# Altium schematic sheet big-auv-power.SchDoc(big-auv-power)
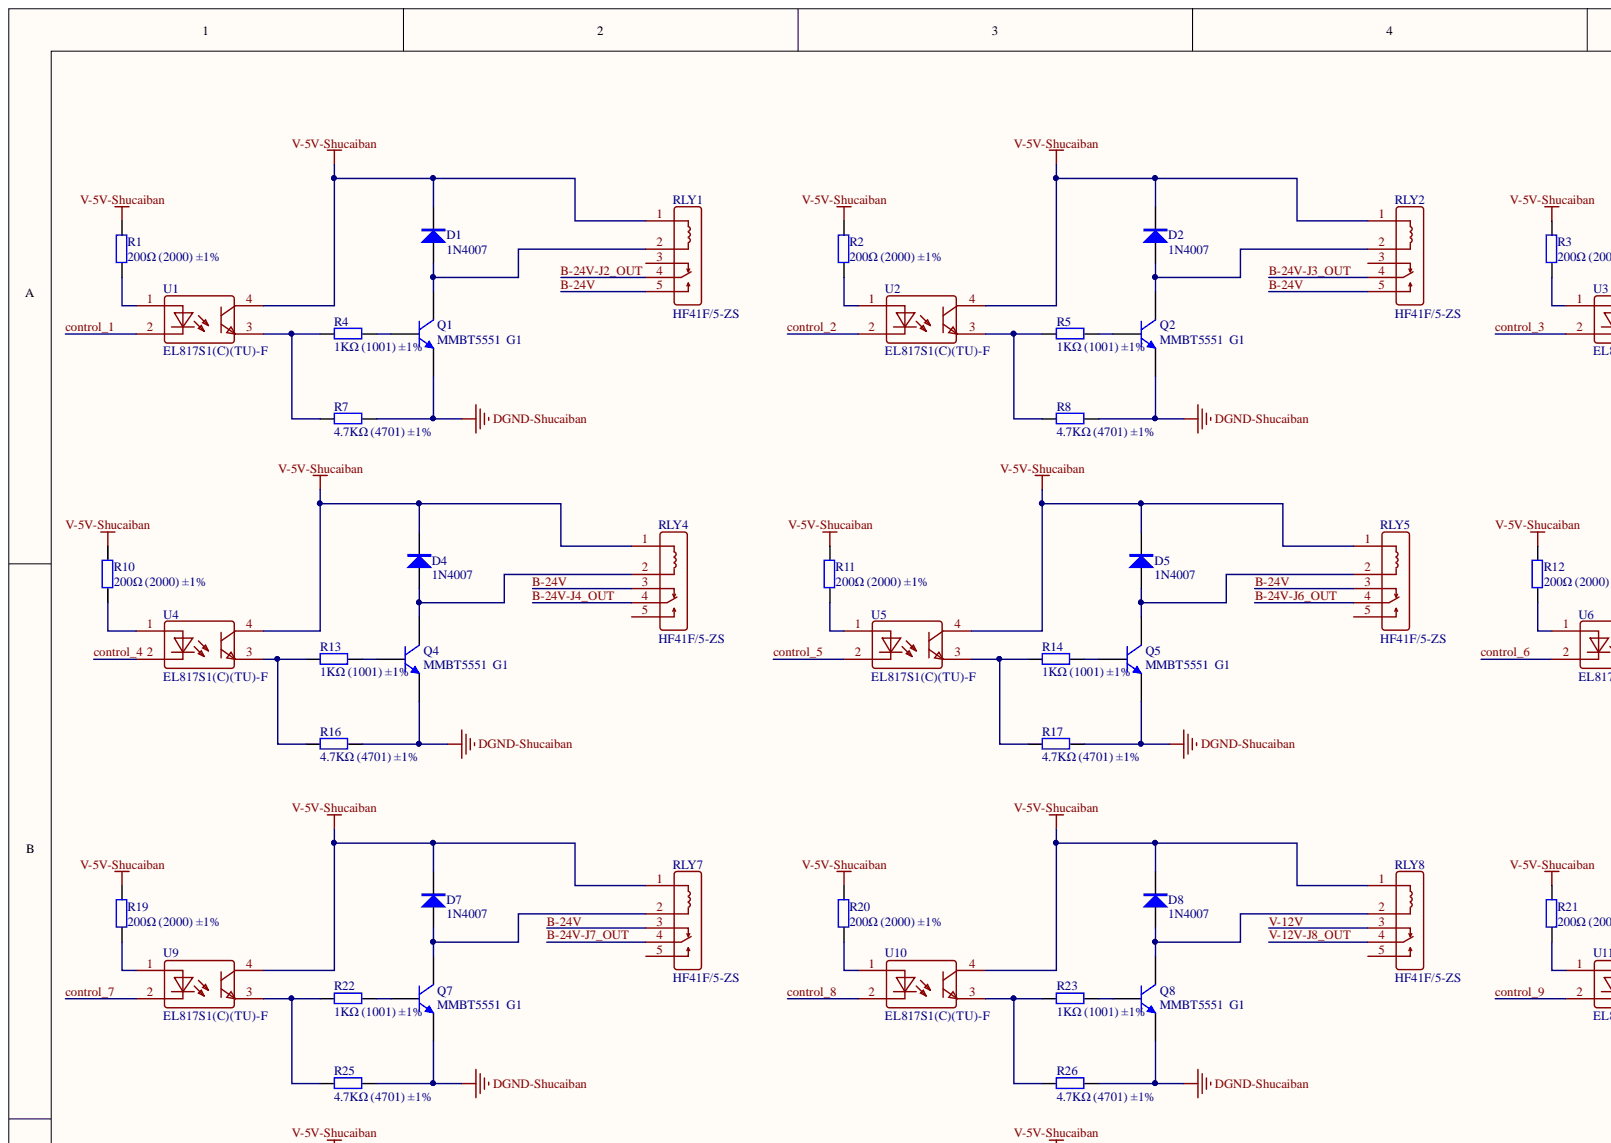
[diagram p0: big-auv-power.SchDoc, top left]
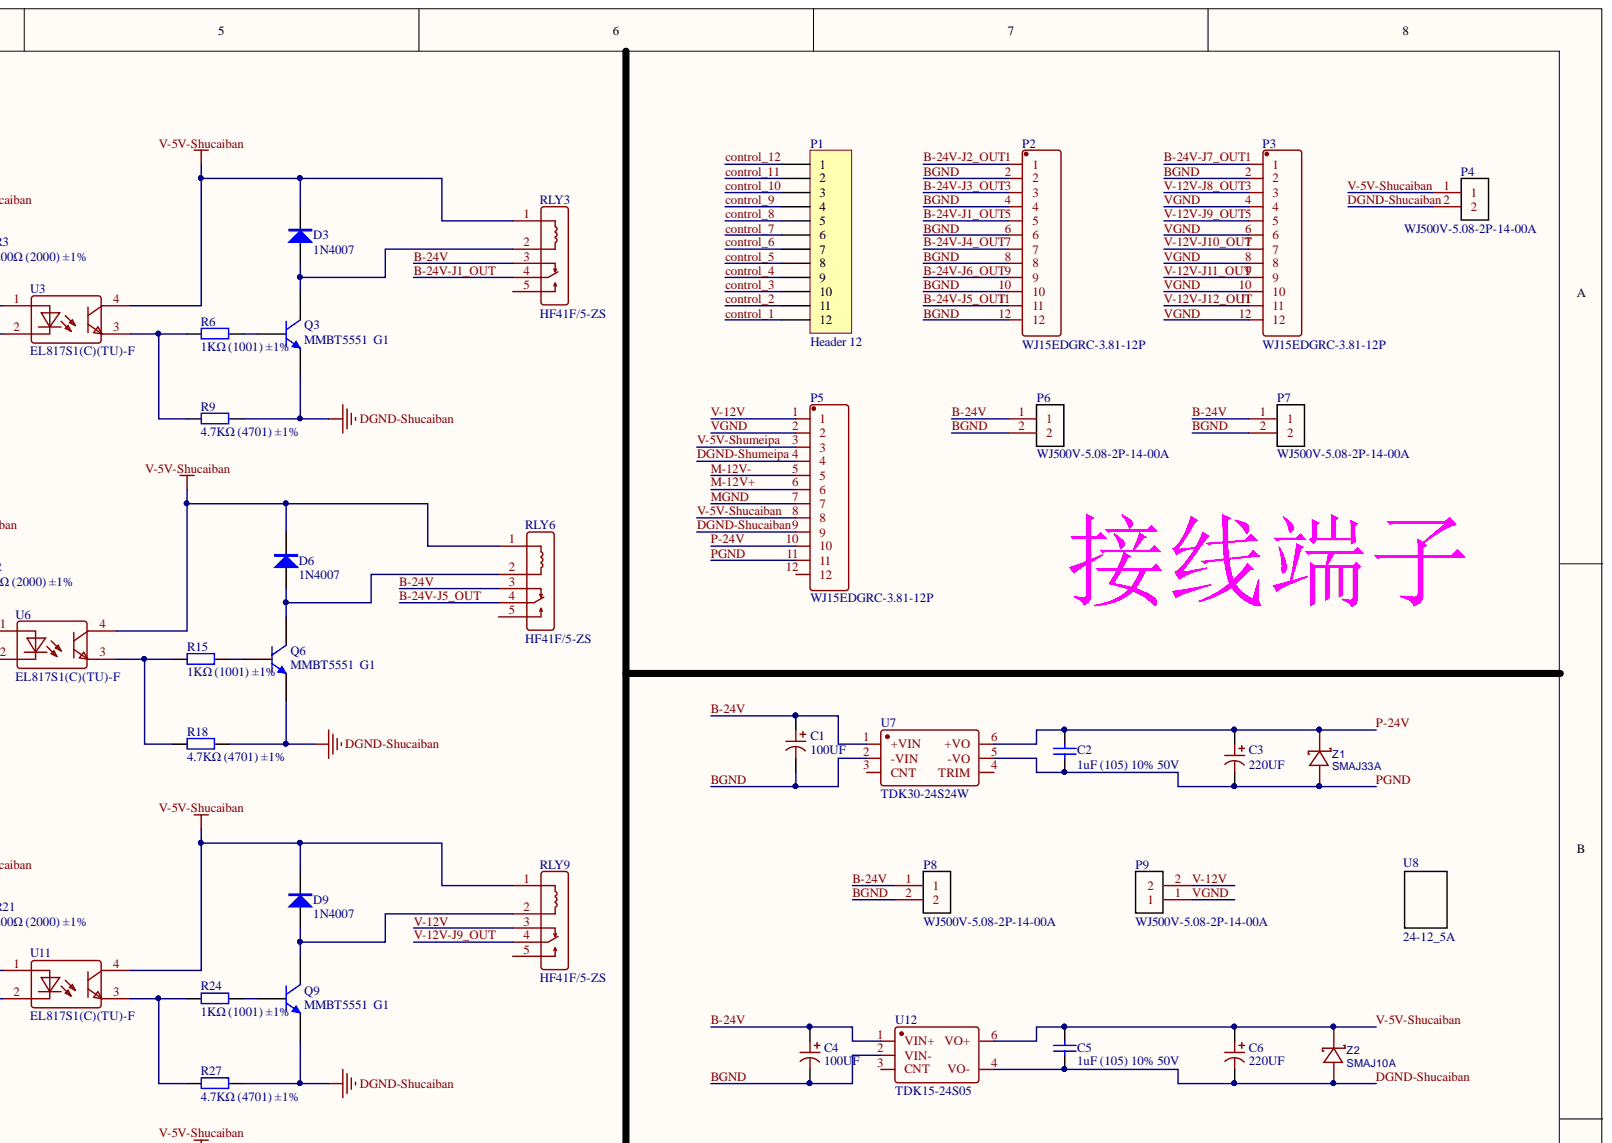
[diagram p1: big-auv-power.SchDoc, top right]
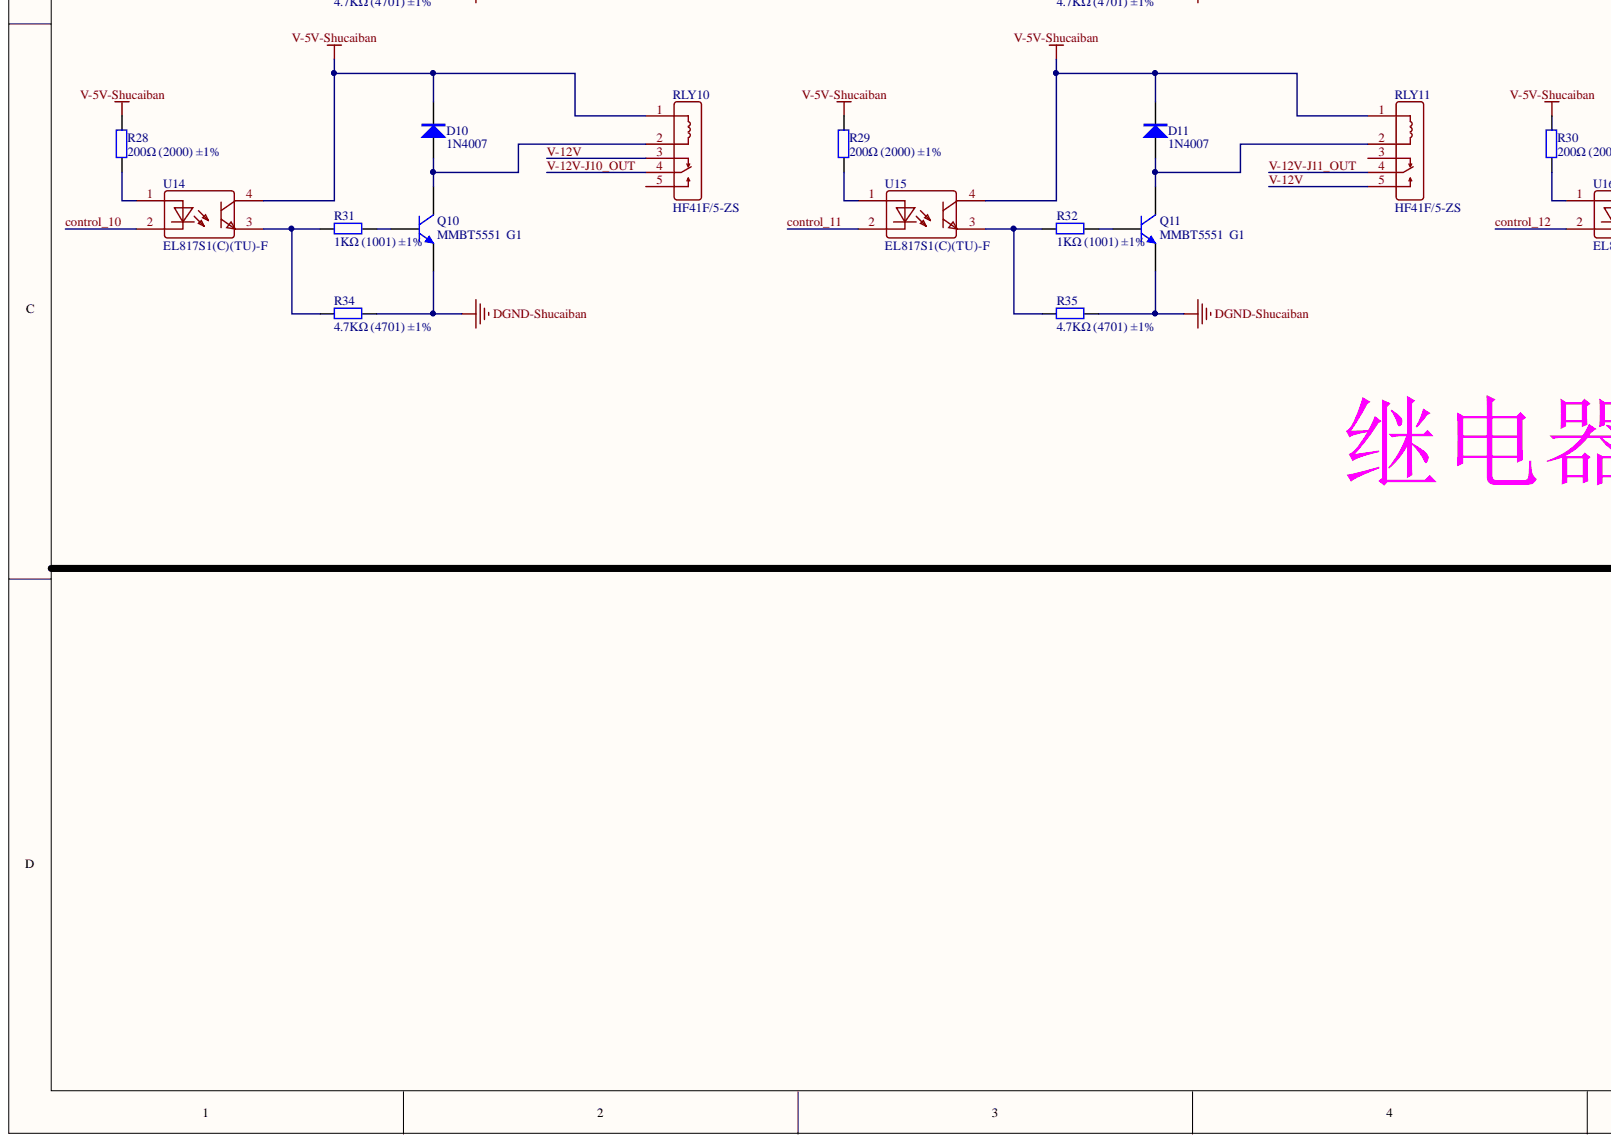
[diagram p2: big-auv-power.SchDoc, bottom left]
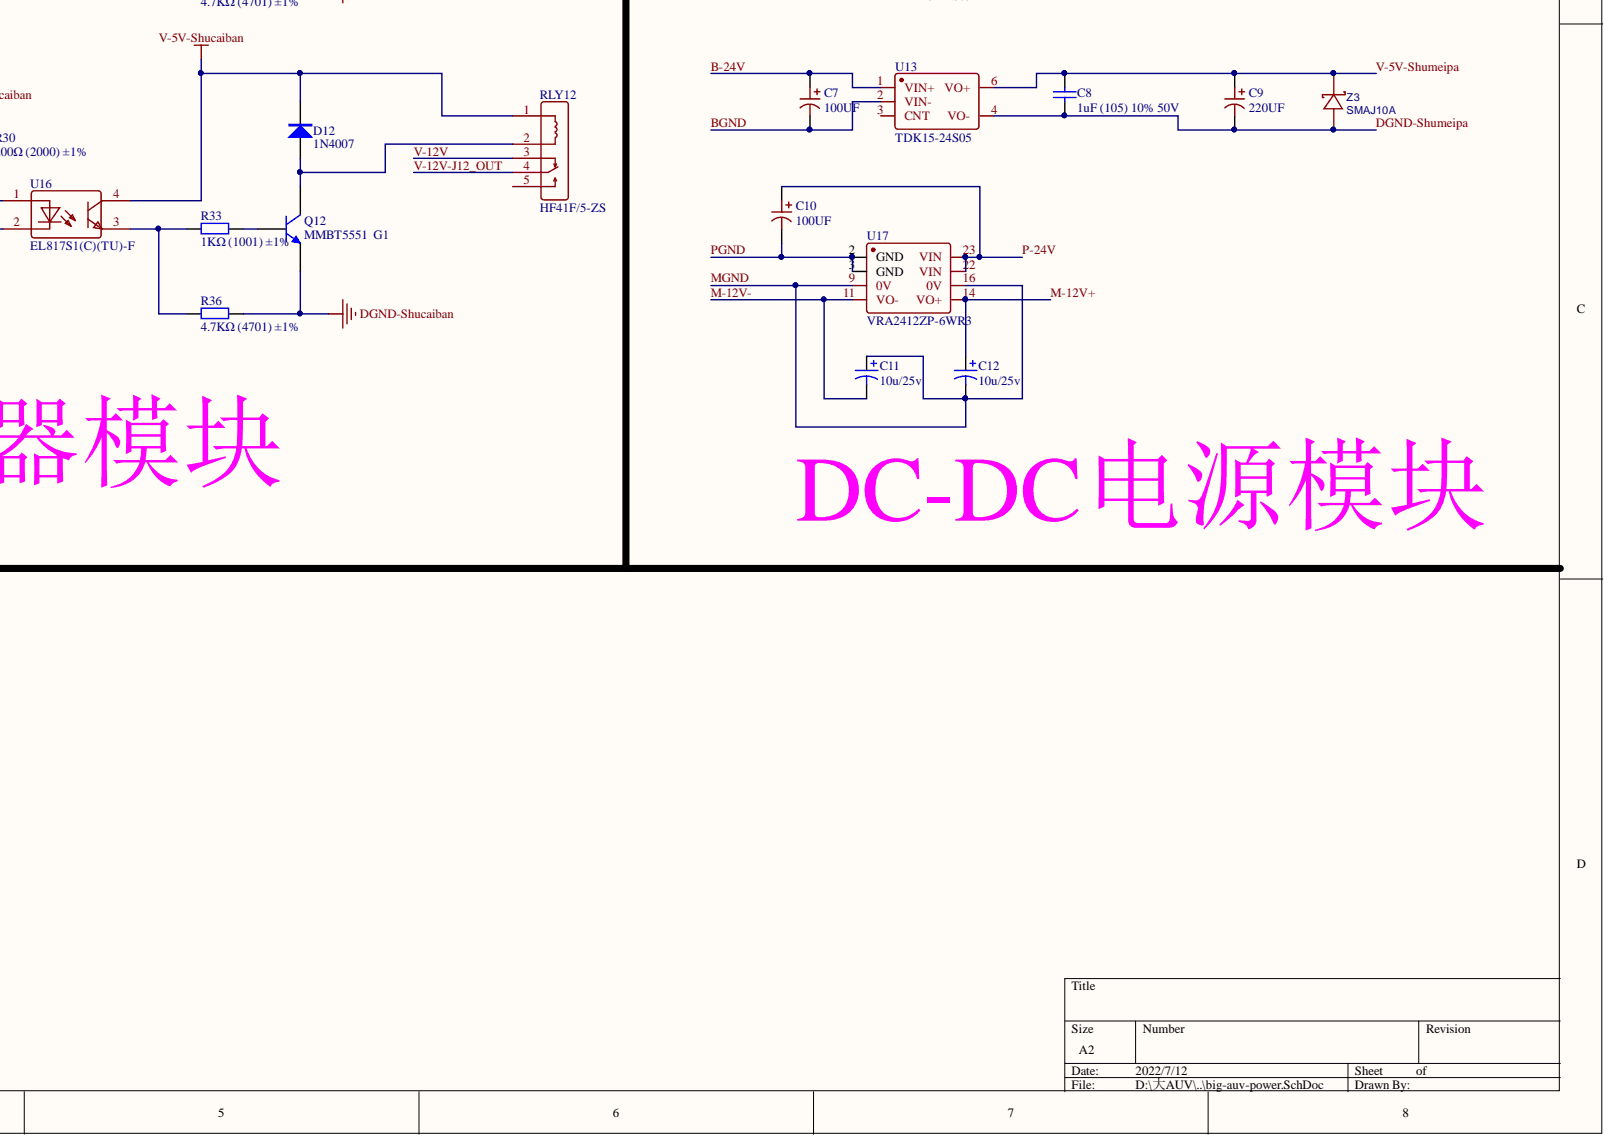
[diagram p3: big-auv-power.SchDoc, bottom right]

## Parts
C1  100UF  [SMD-C]
C2  1uF (105) 10% 50V  [0603_C]
C3  220UF  [SMD-C]
C4  100UF  [SMD-C]
C5  1uF (105) 10% 50V  [0603_C]
C6  220UF  [SMD-C]
C7  100UF  [SMD-C]
C8  1uF (105) 10% 50V  [0603_C]
C9  220UF  [SMD-C]
C10  100UF  [SMD-C]
C11  10u/25v  [SMD-3225-2P]
C12  10u/25v  [SMD-3225-2P]
D1  1N4007  [SMA(DO-214AC)_S2]
D2  1N4007  [SMA(DO-214AC)_S2]
D3  1N4007  [SMA(DO-214AC)_S2]
D4  1N4007  [SMA(DO-214AC)_S2]
D5  1N4007  [SMA(DO-214AC)_S2]
D6  1N4007  [SMA(DO-214AC)_S2]
D7  1N4007  [SMA(DO-214AC)_S2]
D8  1N4007  [SMA(DO-214AC)_S2]
D9  1N4007  [SMA(DO-214AC)_S2]
D10  1N4007  [SMA(DO-214AC)_S2]
D11  1N4007  [SMA(DO-214AC)_S2]
D12  1N4007  [SMA(DO-214AC)_S2]
P1  Header 12  [WE-12-V-F]
P2  WJ15EDGRC-3.81-12P  [WJ15EDGRC-3.81-12P]
P3  WJ15EDGRC-3.81-12P  [WJ15EDGRC-3.81-12P]
P4  WJ500V-5.08-2P-14-00A  [WJ500V-5.08-2P-14-00A]
P5  WJ15EDGRC-3.81-12P  [WJ15EDGRC-3.81-12P]
P6  WJ500V-5.08-2P-14-00A  [WJ500V-5.08-2P-14-00A]
P7  WJ500V-5.08-2P-14-00A  [WJ500V-5.08-2P-14-00A]
P8  WJ500V-5.08-2P-14-00A  [WJ500V-5.08-2P-14-00A]
P9  WJ500V-5.08-2P-14-00A  [WJ500V-5.08-2P-14-00A]
Q1  MMBT5551  G1  [SOT-23(SOT-23-3)]
Q2  MMBT5551  G1  [SOT-23(SOT-23-3)]
Q3  MMBT5551  G1  [SOT-23(SOT-23-3)]
Q4  MMBT5551  G1  [SOT-23(SOT-23-3)]
Q5  MMBT5551  G1  [SOT-23(SOT-23-3)]
Q6  MMBT5551  G1  [SOT-23(SOT-23-3)]
Q7  MMBT5551  G1  [SOT-23(SOT-23-3)]
Q8  MMBT5551  G1  [SOT-23(SOT-23-3)]
Q9  MMBT5551  G1  [SOT-23(SOT-23-3)]
Q10  MMBT5551  G1  [SOT-23(SOT-23-3)]
Q11  MMBT5551  G1  [SOT-23(SOT-23-3)]
Q12  MMBT5551  G1  [SOT-23(SOT-23-3)]
R1  200Ω (2000) ±1%  [0603_R]
R2  200Ω (2000) ±1%  [0603_R]
R3  200Ω (2000) ±1%  [0603_R]
R4  1KΩ (1001) ±1%  [0603_R]
R5  1KΩ (1001) ±1%  [0603_R]
R6  1KΩ (1001) ±1%  [0603_R]
R7  4.7KΩ (4701) ±1%  [0603_R]
R8  4.7KΩ (4701) ±1%  [0603_R]
R9  4.7KΩ (4701) ±1%  [0603_R]
R10  200Ω (2000) ±1%  [0603_R]
R11  200Ω (2000) ±1%  [0603_R]
R12  200Ω (2000) ±1%  [0603_R]
R13  1KΩ (1001) ±1%  [0603_R]
R14  1KΩ (1001) ±1%  [0603_R]
R15  1KΩ (1001) ±1%  [0603_R]
R16  4.7KΩ (4701) ±1%  [0603_R]
R17  4.7KΩ (4701) ±1%  [0603_R]
R18  4.7KΩ (4701) ±1%  [0603_R]
R19  200Ω (2000) ±1%  [0603_R]
R20  200Ω (2000) ±1%  [0603_R]
R21  200Ω (2000) ±1%  [0603_R]
R22  1KΩ (1001) ±1%  [0603_R]
R23  1KΩ (1001) ±1%  [0603_R]
R24  1KΩ (1001) ±1%  [0603_R]
R25  4.7KΩ (4701) ±1%  [0603_R]
R26  4.7KΩ (4701) ±1%  [0603_R]
R27  4.7KΩ (4701) ±1%  [0603_R]
R28  200Ω (2000) ±1%  [0603_R]
R29  200Ω (2000) ±1%  [0603_R]
R30  200Ω (2000) ±1%  [0603_R]
R31  1KΩ (1001) ±1%  [0603_R]
R32  1KΩ (1001) ±1%  [0603_R]
R33  1KΩ (1001) ±1%  [0603_R]
R34  4.7KΩ (4701) ±1%  [0603_R]
R35  4.7KΩ (4701) ±1%  [0603_R]
R36  4.7KΩ (4701) ±1%  [0603_R]
RLY1  HF41F/5-ZS  [HF41F/5-ZS]
RLY2  HF41F/5-ZS  [HF41F/5-ZS]
RLY3  HF41F/5-ZS  [HF41F/5-ZS]
RLY4  HF41F/5-ZS  [HF41F/5-ZS]
RLY5  HF41F/5-ZS  [HF41F/5-ZS]
RLY6  HF41F/5-ZS  [HF41F/5-ZS]
RLY7  HF41F/5-ZS  [HF41F/5-ZS]
RLY8  HF41F/5-ZS  [HF41F/5-ZS]
RLY9  HF41F/5-ZS  [HF41F/5-ZS]
RLY10  HF41F/5-ZS  [HF41F/5-ZS]
RLY11  HF41F/5-ZS  [HF41F/5-ZS]
RLY12  HF41F/5-ZS  [HF41F/5-ZS]
U1  EL817S1(C)(TU)-F  [EL817S1(C)(TU)-F]
U2  EL817S1(C)(TU)-F  [EL817S1(C)(TU)-F]
U3  EL817S1(C)(TU)-F  [EL817S1(C)(TU)-F]
U4  EL817S1(C)(TU)-F  [EL817S1(C)(TU)-F]
U5  EL817S1(C)(TU)-F  [EL817S1(C)(TU)-F]
U6  EL817S1(C)(TU)-F  [EL817S1(C)(TU)-F]
U7  TDK30-24S24W  [TDK30-24S24W]
U8  24-12_5A  [24-12_5A]
U9  EL817S1(C)(TU)-F  [EL817S1(C)(TU)-F]
U10  EL817S1(C)(TU)-F  [EL817S1(C)(TU)-F]
U11  EL817S1(C)(TU)-F  [EL817S1(C)(TU)-F]
U12  TDK15-24S05  [TDK15-24S05]
U13  TDK15-24S05  [TDK15-24S05]
U14  EL817S1(C)(TU)-F  [EL817S1(C)(TU)-F]
U15  EL817S1(C)(TU)-F  [EL817S1(C)(TU)-F]
U16  EL817S1(C)(TU)-F  [EL817S1(C)(TU)-F]
U17  VRA2412ZP-6WR3  [VRA2412ZP-6WR3]
Z1  SMAJ33A  [SMA(DO-214AC)]
Z2  SMAJ10A  [SMA(DO-214AC)]
Z3  SMAJ10A  [SMA(DO-214AC)]

## Nets
B-24V: C1.1 C4.1 C7.1 P6.1 P7.1 P8.1 RLY1.5 RLY2.5 RLY3.3 RLY4.3 RLY5.3 RLY6.3 RLY7.3 U7.1(+VIN) U12.1(VIN+) U13.1(VIN+)
B-24V-J1_OUT: P2.5 RLY3.4
B-24V-J2_OUT: P2.1 RLY1.4
B-24V-J3_OUT: P2.3 RLY2.4
B-24V-J4_OUT: P2.7 RLY4.4
B-24V-J5_OUT: P2.11 RLY6.4
B-24V-J6_OUT: P2.9 RLY5.4
B-24V-J7_OUT: P3.1 RLY7.4
BGND: C1.2 C4.2 C7.2 P2.2 P2.4 P2.6 P2.8 P2.10 P2.12 P3.2 P6.2 P7.2 P8.2 U7.2(-VIN) U12.2(VIN-) U13.2(VIN-)
control_1: P1.12 U1.2
control_2: P1.11 U2.2
control_3: P1.10 U3.2
control_4: P1.9 U4.2
control_5: P1.8 U5.2
control_6: P1.7 U6.2
control_7: P1.6 U9.2
control_8: P1.5 U10.2
control_9: P1.4 U11.2
control_10: P1.3 U14.2
control_11: P1.2 U15.2
control_12: P1.1 U16.2
DGND-Shucaiban: C5.2 C6.2 P4.2 P5.9 Q1.2 Q2.2 Q3.2 Q4.2 Q5.2 Q6.2 Q7.2 Q8.2 Q9.2 Q10.2 Q11.2 Q12.2 R7.2 R8.2 R9.2 R16.2 R17.2 R18.2 R25.2 R26.2 R27.2 R34.2 R35.2 R36.2 U12.4(VO-) Z2.1
DGND-Shumeipa: C8.2 C9.2 P5.4 U13.4(VO-) Z3.1
M-12V+: C12.1 P5.6 U17.14(VO+)
M-12V-: C11.2 P5.5 U17.11(VO-)
MGND: C11.1 C12.2 P5.7 U17.9(0V) U17.16(0V)
P-24V: C2.1 C3.1 C10.1 P5.10 U7.6(+VO) U17.22(VIN) U17.23(VIN) Z1.2
PGND: C2.2 C3.2 C10.2 P5.11 U7.5(-VO) U17.2(GND) U17.3(GND) Z1.1
V-5V-Shucaiban: C5.1 C6.1 D1.2 D2.2 D3.2 D4.2 D5.2 D6.2 D7.2 D8.2 D9.2 D10.2 D11.2 D12.2 P4.1 P5.8 R1.2 R2.2 R3.2 R10.2 R11.2 R12.2 R19.2 R20.2 R21.2 R28.2 R29.2 R30.2 RLY1.1 RLY2.1 RLY3.1 RLY4.1 RLY5.1 RLY6.1 RLY7.1 RLY8.1 RLY9.1 RLY10.1 RLY11.1 RLY12.1 U1.4 U2.4 U3.4 U4.4 U5.4 U6.4 U9.4 U10.4 U11.4 U12.6(VO+) U14.4 U15.4 U16.4 Z2.2
V-5V-Shumeipa: C8.1 C9.1 P5.3 U13.6(VO+) Z3.2
V-12V: P5.1 P9.2 RLY8.3 RLY9.3 RLY10.3 RLY11.5 RLY12.3
V-12V-J8_OUT: P3.3 RLY8.4
V-12V-J9_OUT: P3.5 RLY9.4
V-12V-J10_OUT: P3.7 RLY10.4
V-12V-J11_OUT: P3.9 RLY11.4
V-12V-J12_OUT: P3.11 RLY12.4
VGND: P3.4 P3.6 P3.8 P3.10 P3.12 P5.2 P9.1
NetD1_1: D1.1 Q1.3 RLY1.2
NetD2_1: D2.1 Q2.3 RLY2.2
NetD3_1: D3.1 Q3.3 RLY3.2
NetD4_1: D4.1 Q4.3 RLY4.2
NetD5_1: D5.1 Q5.3 RLY5.2
NetD6_1: D6.1 Q6.3 RLY6.2
NetD7_1: D7.1 Q7.3 RLY7.2
NetD8_1: D8.1 Q8.3 RLY8.2
NetD9_1: D9.1 Q9.3 RLY9.2
NetD10_1: D10.1 Q10.3 RLY10.2
NetD11_1: D11.1 Q11.3 RLY11.2
NetD12_1: D12.1 Q12.3 RLY12.2
NetQ1_1: Q1.1 R4.2
NetQ2_1: Q2.1 R5.2
NetQ3_1: Q3.1 R6.2
NetQ4_1: Q4.1 R13.2
NetQ5_1: Q5.1 R14.2
NetQ6_1: Q6.1 R15.2
NetQ7_1: Q7.1 R22.2
NetQ8_1: Q8.1 R23.2
NetQ9_1: Q9.1 R24.2
NetQ10_1: Q10.1 R31.2
NetQ11_1: Q11.1 R32.2
NetQ12_1: Q12.1 R33.2
NetR1_1: R1.1 U1.1
NetR2_1: R2.1 U2.1
NetR3_1: R3.1 U3.1
NetR4_1: R4.1 R7.1 U1.3
NetR5_1: R5.1 R8.1 U2.3
NetR6_1: R6.1 R9.1 U3.3
NetR10_1: R10.1 U4.1
NetR11_1: R11.1 U5.1
NetR12_1: R12.1 U6.1
NetR13_1: R13.1 R16.1 U4.3
NetR14_1: R14.1 R17.1 U5.3
NetR15_1: R15.1 R18.1 U6.3
NetR19_1: R19.1 U9.1
NetR20_1: R20.1 U10.1
NetR21_1: R21.1 U11.1
NetR22_1: R22.1 R25.1 U9.3
NetR23_1: R23.1 R26.1 U10.3
NetR24_1: R24.1 R27.1 U11.3
NetR28_1: R28.1 U14.1
NetR29_1: R29.1 U15.1
NetR30_1: R30.1 U16.1
NetR31_1: R31.1 R34.1 U14.3
NetR32_1: R32.1 R35.1 U15.3
NetR33_1: R33.1 R36.1 U16.3

Not connected: P5 12; RLY1 3; RLY2 3; RLY3 5; RLY4 5; RLY5 5; RLY6 5; RLY7 5; RLY8 5; RLY9 5; RLY10 5; RLY11 3; RLY12 5; U7 3(CNT) 4(TRIM); U12 3(CNT); U13 3(CNT)

## Library data of the parts
C2 C5 C8 = 0603 1uF (105) 10% 50V: 贴片电容; SuppliersPartNumber: C15849; Supplier: LC; Package: 0603; Library Name: 2-Cap.SchLib; ComponentLink1URL: http://www.szlcsc.com/product/details_16531.html; ComponentLink1Description: 供应商链接; Component Kind: Standard
C11 C12 = CAP[B]: Library Name: NewMag.IntLib; Component Kind: Standard
D1-D12 = 1N4007: 整流二极管; 版权归属: 源创客; 学习资源: www.ooocke.com; Library Name: 4-Diode.SchLib; Component Kind: Standard; BOM名称: 整流二极管
P1 = Header 12: Header, 12-Pin; Signal Integrity: Connector; Library Name: Miscellaneous Connectors.IntLib; LatestRevisionNote: Re-released for DXP Platform.; LatestRevisionDate: 17-Jul-2002; Component Kind: Standard; Publisher: Altium Limited
Q1-Q12 = SOT-23 MMBT5551  G1: 三极管; SuppliersPartNumber: C2145; Supplier: LC; Package: SOT-23; Library Name: 7-Transistor_NPN.SchLib; ComponentLink1URL: http://www.szlcsc.com/product/details_2502.html; ComponentLink1Description: 供应商链接; Component Kind: Standard
R1-R3 R10-R12 R19-R21 R28-R30 = 0603 200Ω (2000) 1%: 贴片电阻; SuppliersPartNumber: C8218; Supplier: LC; Package: 0603; Library Name: 1-RES.SchLib; ComponentLink1URL: http://www.szlcsc.com/product/details_8708.html; ComponentLink1Description: 供应商链接; Component Kind: Standard
R4-R6 R13-R15 R22-R24 R31-R33 = 0603 1KΩ (1001) 1%: 贴片电阻; SuppliersPartNumber: C21190; Supplier: LC; Package: 0603; Library Name: 1-RES.SchLib; ComponentLink1URL: http://www.szlcsc.com/product/details_21904.html; ComponentLink1Description: 供应商链接; Component Kind: Standard
R7-R9 R16-R18 R25-R27 R34-R36 = 0603 4.7KΩ (4701) 1%: 贴片电阻; SuppliersPartNumber: C23162; Supplier: LC; Package: 0603; Library Name: 1-RES.SchLib; ComponentLink1URL: http://www.szlcsc.com/product/details_23889.html; ComponentLink1Description: 供应商链接; Component Kind: Standard
Z1-Z3 = SMBJ10A: TVS二极管; BOM_Manufacturer: Littelfuse; SMT Type: Extend; Contributor: LCSC; Value: SMBJ10A; URL: https://item.szlcsc.com/162590.html; spicePre: D; Library Name: 4-Diode.SchLib; Component Kind: Standard; BOM_Supplier Part: C151250; BOM_Supplier: LCSC; BOM_Manufacturer Part: SMBJ10A; BOM_LCSC Assembly: Yes
C1 C4 C7 C10 = 100UF: Component Kind: Standard; Library Name: big-auv-power.SchLib
C3 C6 C9 = 220UF: Component Kind: Standard; Library Name: big-auv-power.SchLib
P2 P3 P5 = WJ15EDGRC-3.81-12P: Component Kind: Standard; Library Name: big-auv-power.SchLib
P4 P6-P9 = WJ500V-5.08-2P-14-00A: Component Kind: Standard; Library Name: big-auv-power.SchLib
RLY1-RLY12 = HF41F/5-ZS: Component Kind: Standard; Library Name: big-auv-power.SchLib
U1-U6 U9-U11 U14-U16 = EL817S1(C)(TU)-F: Component Kind: Standard; Library Name: big-auv-power.SchLib
U7 = TDK30-24S24W: Component Kind: Standard; Library Name: big-auv-power.SchLib
U8 = 24-12_5A: Component Kind: Standard; Library Name: big-auv-power.SchLib
U12 U13 = TDK15-24S05: Component Kind: Standard; Library Name: big-auv-power.SchLib
U17 = VRA2412ZP-6WR3: Component Kind: Standard; Library Name: big-auv-power.SchLib
